# Altium schematic sheet [1] Controller.SchDoc
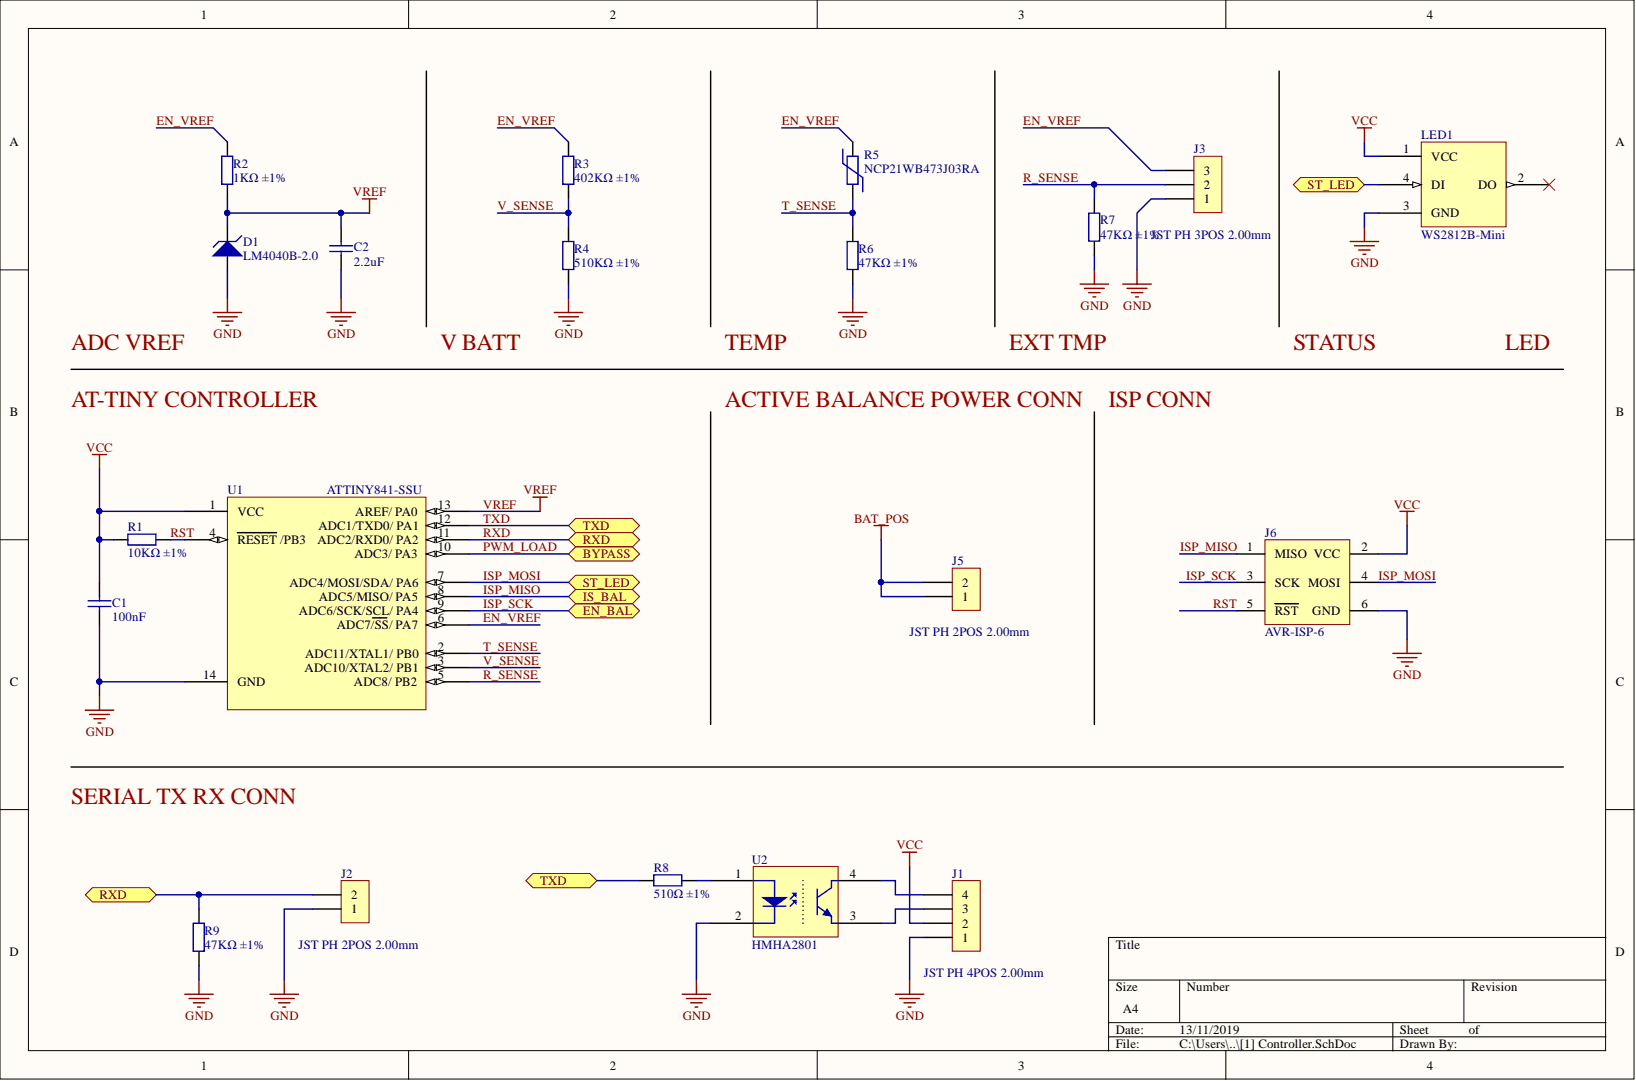
[diagram p0: [1] Controller.SchDoc]

## Parts
C1  100nF  [0805_C]
C2  2.2uF  [0805_C]
D1  LM4040B-2.0  [SOT-23(SOT-23-3)]
J1  JST PH 4POS 2.00mm  [JST_PH_S4B]
J2  JST PH 2POS 2.00mm  [JST_PH_S2B]
J3  JST PH 3POS 2.00mm  [JST_PH_S3B]
J5  JST PH 2POS 2.00mm  [JST_PH_S2B]
J6  AVR-ISP-6  [PINHEADER_6POS_2ROW_2.54]
LED1  WS2812B-Mini  [LED3535_4P]
R1  10KΩ ±1%  Resistance: 10KΩ  [0805_R]
R2  1KΩ ±1%  Resistance: 1KΩ  [0805_R]
R3  402KΩ ±1%  [0805_R]
R4  510KΩ ±1%  [0805_R]
R5  NCP21WB473J03RA  [0805_R]
R6  47KΩ ±1%  Resistance: 47KΩ  [0805_R]
R7  47KΩ ±1%  Resistance: 47KΩ  [0805_R]
R8  510Ω ±1%  Resistance: 510Ω  [0805_R]
R9  47KΩ ±1%  Resistance: 47KΩ  [0805_R]
U1  ATTINY841-SSU  [SOIC-14_150mil]
U2  HMHA2801  [HMHA2801]

## Ports
BYPASS, EN_BAL, IS_BAL, RXD, ST_LED, TXD

## Nets
BAT_POS: J5.1 J5.2
GND: C1.1 C2.1 D1.2 J1.1 J2.1 J3.1 J6.6(GND) LED1.3(GND) R4.1 R6.1 R7.1 R9.1 U1.14(GND) U2.2
VCC: C1.2 J1.2 J6.2(VCC) LED1.1(VCC) R1.2 U1.1(VCC)
EN_VREF: J3.3 R2.2 R3.2 R5.2 U1.6(ADC7/SS/_PA7)
ISP_MISO: J6.1(MISO) U1.8(ADC5/MISO/_PA5) ; port IS_BAL
ISP_MOSI: J6.4(MOSI) LED1.4(DI) U1.7(ADC4/MOSI/SDA/_PA6) ; port ST_LED
ISP_SCK: J6.3(SCK) U1.9(ADC6/SCK/SCL/_PA4) ; port EN_BAL
PWM_LOAD: U1.10(ADC3/_PA3) ; port BYPASS
R_SENSE: J3.2 R7.2 U1.5(ADC8/_PB2)
RST: J6.5(RST) R1.1 U1.4(RESET_/PB3)
RXD: J2.2 R9.2 U1.11(ADC2/RXD0/_PA2) ; port RXD
ST_LED: LED1.4(DI) ; port ST_LED
T_SENSE: R5.1 R6.2 U1.2(ADC11/XTAL1/_PB0)
TXD: R8.1 U1.12(ADC1/TXD0/_PA1) ; port TXD
V_SENSE: R3.1 R4.2 U1.3(ADC10/XTAL2/_PB1)
VREF: C2.2 D1.1 R2.1 U1.13(AREF/_PA0)
NetJ1_3: J1.3 U2.3
NetJ1_4: J1.4 U2.4
NetR8_1: R8.1 ; port TXD
NetR8_2: R8.2 U2.1

Not connected: LED1 2(DO)

## Library data of the parts
C1 = 0805 100nF(104) 10% 50V: Chip capacitors; Manufacturer: YAGEO; PartNumber: CC0805KRX7R9BB104; Tolerance: 10%; Voltage: 50V; Capacitance: 100nF; Component Kind: Standard; ComponentLink1Description: Supplier link; ComponentLink1URL: http://www.szlcsc.com/product/details_50686.html; Library Name: Miscellaneous Devices LC.IntLib; Package: 0805; Supplier: LC; SuppliersPartNumber: C49678
C2 = 0805 2.2uF (225) 20% 50V: Chip capacitors; Manufacturer: Guangdong Fenghua Advanced Tech; PartNumber: 0805F225M500NT; Tolerance: 20%; Voltage: 50V; Capacitance: 2.2uF; Component Kind: Standard; ComponentLink1Description: Supplier link; ComponentLink1URL: http://www.szlcsc.com/product/details_50225.html; Library Name: Miscellaneous Devices LC.IntLib; Package: 0805; Supplier: LC; SuppliersPartNumber: C49217
D1 = LM4040B-2.0: Precision Shunt Voltage Reference; Component Kind: Standard; Library Name: BMS_SchLib.SchLib
J1 = JST_PH_4POS: JST-PH Conn; Manufacturer: JST Sales America; PartNumber: S4B-PH-K-S(LF)(SN); Positions: 3; Row: 1; Component Kind: Standard; ComponentLink1Description: Datasheet; ComponentLink1URL: https://datasheet.lcsc.com/szlcsc/1810261107_JST-Sales-America-S4B-PH-K-S-LF-SN_C157926.pdf; ComponentLink2Description: Supplier link; ComponentLink2URL: https://lcsc.com/product-detail/Wire-To-Board-Wire-To-Wire-Connector_JST-Sales-America-S4B-PH-K-S-LF-SN_C157926.html; Library Name: BMS_SchLib.SchLib; Supplier: LC; SuppliersPartNumber: C157926; Type: Male
J2 J5 = JST_PH_2POS: JST-PH Conn; Manufacturer: JST Sales America; PartNumber: S2B-PH-K-S(LF)(SN); Positions: 2; Row: 1; Component Kind: Standard; ComponentLink1Description: Datasheet; ComponentLink1URL: https://datasheet.lcsc.com/szlcsc/JST-Sales-America-S2B-PH-K-S-LF-SN_C173752.pdf; ComponentLink2Description: Supplier link; ComponentLink2URL: https://lcsc.com/product-detail/Wire-To-Board-Wire-To-Wire-Connector_JST-Sales-America-S2B-PH-K-S-LF-SN_C173752.html; Library Name: BMS_SchLib.SchLib; Supplier: LC; SuppliersPartNumber: C173752; Type: Male
J3 = JST_PH_3POS: JST-PH Conn; Manufacturer: JST Sales America; PartNumber: S3B-PH-K-S(LF)(SN); Positions: 3; Row: 1; Component Kind: Standard; ComponentLink1Description: Datasheet; ComponentLink1URL: https://datasheet.lcsc.com/szlcsc/1810261120_JST-Sales-America-S3B-PH-K-S-LF-SN_C157929.pdf; ComponentLink2Description: Supplier link; ComponentLink2URL: https://lcsc.com/product-detail/Wire-To-Board-Wire-To-Wire-Connector_JST-Sales-America_S3B-PH-K-S-LF-SN_JST-Sales-America-S3B-PH-K-S-LF-SN_C157929.html; Library Name: BMS_SchLib.SchLib; Supplier: LC; SuppliersPartNumber: C157929; Type: Male
J6 = AVR_ISP: Manufacturer: Boom Precision Elec; PartNumber: C65114; Positions: 6; Row: 2; Component Kind: Standard; Library Name: BMS_SchLib.SchLib; Supplier: LC; SuppliersPartNumber: C65114; Type: Male
LED1 = WS2812B-Mini: RGB Addressable LED; Manufacturer: Worldsemi; Component Kind: Standard; ComponentLink1Description: Supplier link; ComponentLink1URL: https://lcsc.com/product-detail/Light-Emitting-Diodes-LED_Worldsemi-WS2812B-Mini_C114583.html; Library Name: BMS_SchLib.SchLib; Package: 3535; PartNumber: WS2812B-Mini; Supplier: LC; SuppliersPartNumber: C114583
R1 = 0805 10K (1002) 1%: Chip resistor; Manufacturer: Uniroyal Elec; PartNumber: 0805W8F1002T5E; Power: 1/8W; Component Kind: Standard; ComponentLink1Description: Supplier link; ComponentLink1URL: http://www.szlcsc.com/product/details_18102.html; Library Name: RES.SchLib; Package: 0805; Supplier: LC; SuppliersPartNumber: C17414; Tolerance: 1%
R2 = 0805 1K (1001) 1%: Chip resistor; Manufacturer: Uniroyal Elec; PartNumber: 0805W8F1001T5E; Power: 1/8W; Component Kind: Standard; ComponentLink1Description: Supplier link; ComponentLink1URL: http://www.szlcsc.com/product/details_18201.html; Library Name: RES.SchLib; Package: 0805; Supplier: LC; SuppliersPartNumber: C17513; Tolerance: 1%
R3 = 0805 402K (4023) 1%: Chip resistor; Manufacturer: Uniroyal Elec; PartNumber: 0805W8F4023T5E; Power: 1/8W; Resistance: 402K; Component Kind: Standard; ComponentLink1Description: Supplier link; ComponentLink1URL: https://lcsc.com/product-detail/Chip-Resistor-Surface-Mount_Uniroyal-Elec-0805W8F4023T5E_C17680.html; Library Name: BMS_SchLib.SchLib; Package: 0805; Supplier: LC; SuppliersPartNumber: C17680; Tolerance: 1%
R4 = 0805 510K (5103) 1%: Chip resistor; Manufacturer: Uniroyal Elec; PartNumber: 0805W8F4023T5E; Power: 1/8W; Resistance: 510K; Component Kind: Standard; ComponentLink1Description: Supplier link; ComponentLink1URL: https://lcsc.com/product-detail/Chip-Resistor-Surface-Mount_Uniroyal-Elec-0805W8F5103T5E_C17733.html; Library Name: BMS_SchLib.SchLib; Package: 0805; Supplier: LC; SuppliersPartNumber: C17733; Tolerance: 1%
R5 = 0805 NTC 47K 5%: NTC Resistor 47K 5%; Manufacturer: Murata Electronics; B Tolerance: 3%; B0/50: 4050K; Power: 1/5W; Component Kind: Standard; ComponentLink1Description: Supplier link; ComponentLink1URL: https://lcsc.com/product-detail/NTC-Thermistors_Murata-Electronics-NCP21WB473J03RA_C113141.html; Library Name: BMS_SchLib.SchLib; Package: 0805; PartNumber: NCP21WB473J03RA; Resistance @25°C: 47K; Supplier: LC; SuppliersPartNumber: C113141; Tolerance: 5%
R6 R7 R9 = 0805 47K (4702) 1%: Chip resistor; Manufacturer: Uniroyal Elec; PartNumber: 0805W8F4702T5E; Power: 1/8W; Component Kind: Standard; ComponentLink1Description: Supplier link; ComponentLink1URL: http://www.szlcsc.com/product/details_18401.html; Library Name: RES.SchLib; Package: 0805; Supplier: LC; SuppliersPartNumber: C17713; Tolerance: 1%
R8 = 0805 510R (5100) 1%: Chip resistor; Manufacturer: Uniroyal Elec; PartNumber: 0805W8F5100T5E; Power: 1/8W; Component Kind: Standard; ComponentLink1Description: Supplier link; ComponentLink1URL: http://www.szlcsc.com/product/details_18422.html; Library Name: RES.SchLib; Package: 0805; Supplier: LC; SuppliersPartNumber: C17734; Tolerance: 1%
U1 = ATTINY841: AtTiny AVR; Manufacturer: Microchip Tech; Component Kind: Standard; ComponentLink1Description: Datasheet; ComponentLink1URL: http://ww1.microchip.com/downloads/en/DeviceDoc/Atmel-8495-8-bit-AVR-Microcontrollers-ATtiny441-ATtiny841_Datasheet.pdf; ComponentLink2Description: Supplier link; ComponentLink2URL: https://lcsc.com/product-detail/ATMEL-AVR_Microchip-Tech-ATTINY841-SSU_C219103.html; Library Name: BMS_SchLib.SchLib; Package: SOIC-14; PartNumber: ATTINY841-SSU; Supplier: LC; SuppliersPartNumber: C219103
U2 = HMHA2801: Optocoupler; Manufacturer: ON Semicon; PartNumber: HMHA2801AR2; Component Kind: Standard; ComponentLink1Description: Supplier Link; ComponentLink1URL: https://lcsc.com/product-detail/Optocouplers_ON-Semicon_HMHA2801AR2_ON-Semicon-ON-HMHA2801AR2_C258257.html; Library Name: BMS_SchLib.SchLib; Package: SOIC-4; Supplier: LC; SuppliersPartNumber: C258257
